# Altium schematic sheet Sensor-Sheet4.SchDoc(Sensor-Sheet4)
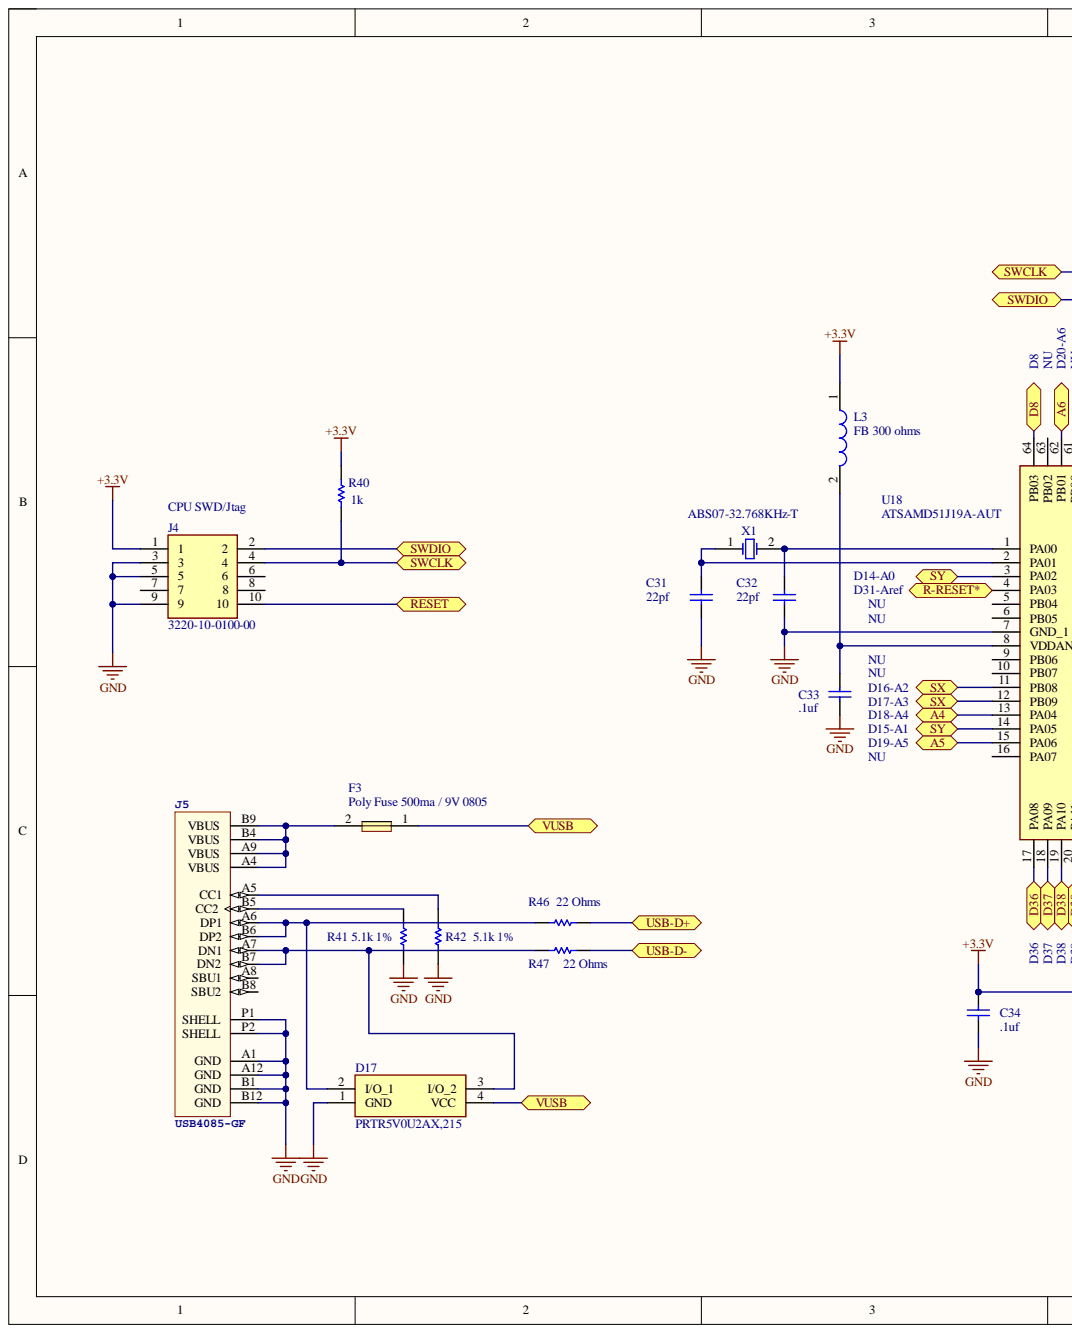
[diagram p0: Sensor-Sheet4.SchDoc, left]
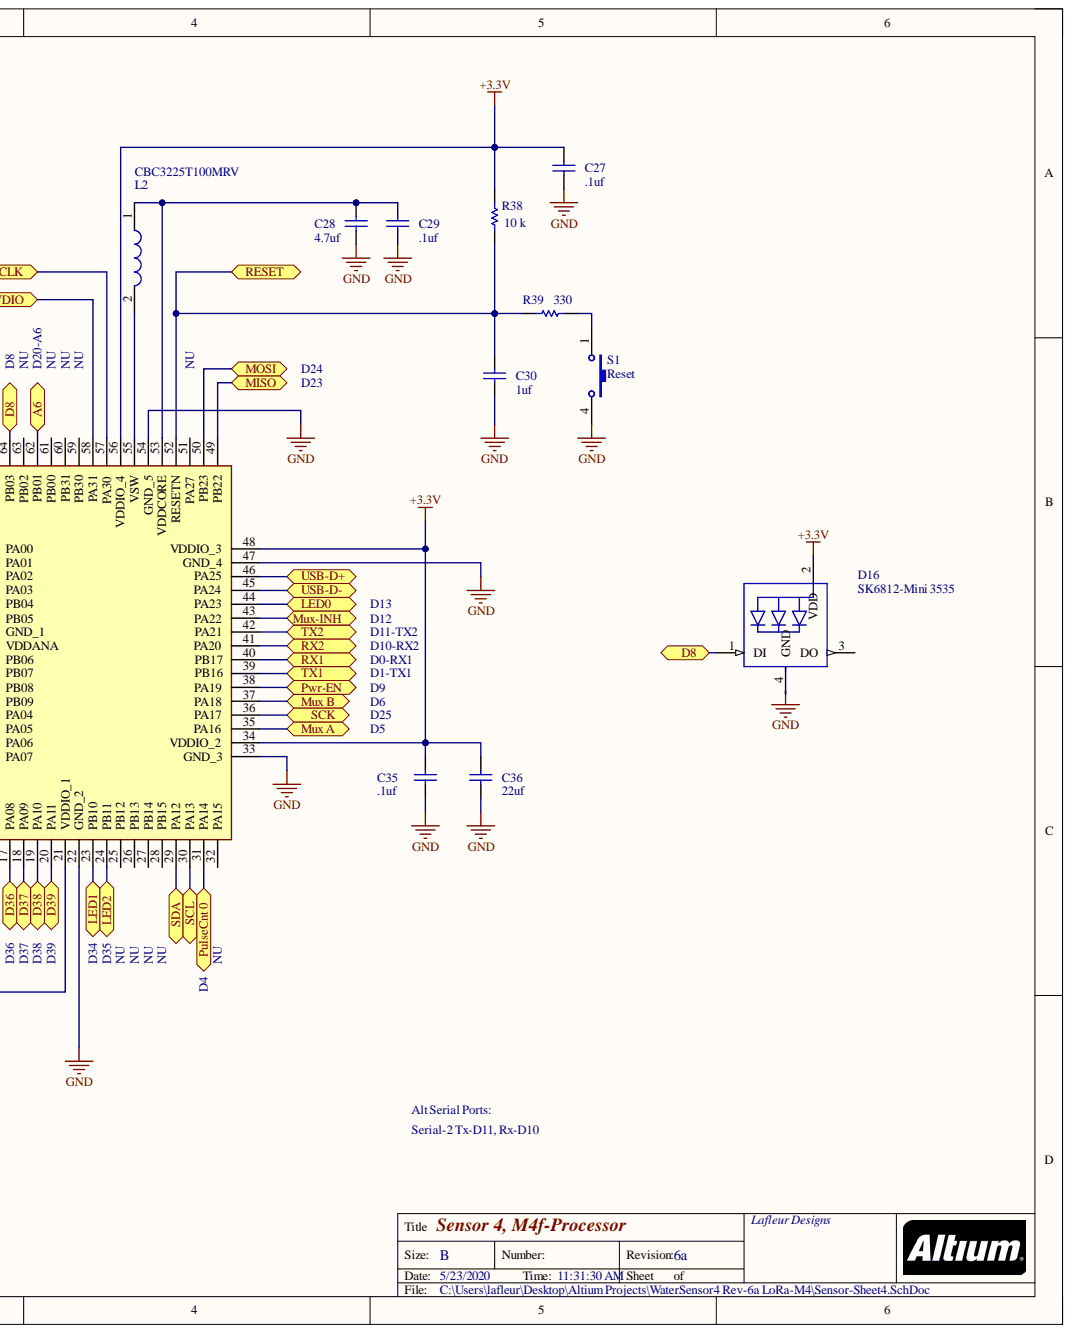
[diagram p1: Sensor-Sheet4.SchDoc, right]

## Parts
C27  .1uf  [c0805]
C28  4.7uf  [C0805]
C29  .1uf  [c0805]
C30  1uf  [c0805]
C31  22pf  [c0805]
C32  22pf  [c0805]
C33  .1uf  [c0805]
C34  .1uf  [c0805]
C35  .1uf  [c0805]
C36  22uf  [c0805]
D16  SK6812-Mini 3535  [SK6816Mini-35x35]
D17  PRTR5V0U2AX,215  [PESD2ETHAXR]
F3  Poly Fuse 500ma / 9V  [6-0805]
J4  3220-10-0100-00  [SHDR10W41P127_2X5_1265X510X565P]
J5  USB4085-GF  [USB4085-GF-A]
L2  CBC3225T100MRV  [INDC3225X270N]
L3  FB 300 ohms  [INDC2012X85N]
R38  10 k  [6-0805]
R39  330  [6-0805]
R40  1k  [6-0805]
R41  5.1k 1%  [6-0805]
R42  5.1k 1%  [6-0805]
R46  22 Ohms  [6-0805]
R47  22 Ohms  [6-0805]
S1  Reset  [KMR_2]
U18  ATSAMD51J19A-AUT  [QFP50P1200X1200X120-64N]
X1  ABS07-32.768KHz-T  [ABRA-ABS07-2_V]

## Ports
A4, A5, A6, D8, D36, D37, D38, D39, LED0, LED1, LED2, MISO, MOSI, Mux A, Mux B, Mux-INH, PulseCnt 0, Pwr-EN, R-RESET*, RESET, RX1, RX2, SCK, SCL, SDA, SWCLK, SWDIO, SX, SY, TX1, TX2, USB-D+, USB-D-, VUSB

## Nets
+3.3V: C27.1 C34.1 C35.1 C36.1 D16.2(VDD) J4.1 L3.1 R38.2 R40.2 U18.21(VDDIO_1) U18.34(VDDIO_2) U18.48(VDDIO_3) U18.56(VDDIO_4)
GND: C27.2 C28.2 C29.2 C30.2 C31.2 C32.2 C33.2 C34.2 C35.2 C36.2 D16.4(GND) D17.1(GND) J4.3 J4.5 J4.9 J5.A1(GND) J5.A12(GND) J5.B1(GND) J5.B12(GND) J5.P1(SHELL) J5.P2(SHELL) R41.2 R42.2 S1.4 U18.7(GND_1) U18.22(GND_2) U18.33(GND_3) U18.47(GND_4) U18.54(GND_5)
A4: U18.13(PA04) ; port A4
A5: U18.15(PA06) ; port A5
A6: U18.62(PB01) ; port A6
D8: D16.1(DI) U18.64(PB03) ; port D8
D36: U18.17(PA08) ; port D36
D37: U18.18(PA09) ; port D37
D38: U18.19(PA10) ; port D38
D39: U18.20(PA11) ; port D39
LED0: U18.44(PA23) ; port LED0
LED1: U18.23(PB10) ; port LED1
LED2: U18.24(PB11) ; port LED2
MISO: U18.49(PB22) ; port MISO
MOSI: U18.50(PB23) ; port MOSI
Mux A: U18.35(PA16) ; port Mux A
Mux B: U18.37(PA18) ; port Mux B
Mux-INH: U18.43(PA22) ; port Mux-INH
PulseCnt 0: U18.31(PA14) ; port PulseCnt 0
Pwr-EN: U18.38(PA19) ; port Pwr-EN
R-RESET*: U18.4(PA03) ; port R-RESET*
RESET: C30.1 J4.10 R38.1 R39.2 U18.52(RESETN) ; port RESET
RX1: U18.40(PB17) ; port RX1
RX2: U18.41(PA20) ; port RX2
SCK: U18.36(PA17) ; port SCK
SCL: U18.30(PA13) ; port SCL
SDA: U18.29(PA12) ; port SDA
SWCLK: J4.4 R40.1 U18.57(PA30) ; port SWCLK
SWDIO: J4.2 U18.58(PA31) ; port SWDIO
SX: U18.11(PB08) U18.12(PB09) ; port SX
SY: U18.3(PA02) U18.14(PA05) ; port SY
TX1: U18.39(PB16) ; port TX1
TX2: U18.42(PA21) ; port TX2
VUSB: D17.4(VCC) F3.1 ; port VUSB
NetC28_1: C28.1 C29.1 L2.1 U18.53(VDDCORE)
NetC31_1: C31.1 U18.2(PA01) X1.1
NetC32_1: C32.1 U18.1(PA00) X1.2
NetC33_1: C33.1 L3.2 U18.8(VDDANA)
NetD16_3: D16.3(DO)
NetD17_2: D17.2(I/O_1) J5.A6(DP1) J5.B6(DP2) R46.1
NetD17_3: D17.3(I/O_2) J5.A7(DN1) J5.B7(DN2) R47.2
NetF3_2: F3.2 J5.A4(VBUS) J5.A9(VBUS) J5.B4(VBUS) J5.B9(VBUS)
NetJ4_6: J4.6
NetJ4_7: J4.7
NetJ4_8: J4.8
NetJ5_A5: J5.A5(CC1) R42.1
NetJ5_A8: J5.A8(SBU1)
NetJ5_B5: J5.B5(CC2) R41.1
NetJ5_B8: J5.B8(SBU2)
NetL2_2: L2.2 U18.55(VSW)
NetR39_1: R39.1 S1.1
NetR46_2: R46.2 U18.46(PA25)
NetR47_1: R47.1 U18.45(PA24)
NetU18_5: U18.5(PB04)
NetU18_6: U18.6(PB05)
NetU18_9: U18.9(PB06)
NetU18_10: U18.10(PB07)
NetU18_16: U18.16(PA07)
NetU18_25: U18.25(PB12)
NetU18_26: U18.26(PB13)
NetU18_27: U18.27(PB14)
NetU18_28: U18.28(PB15)
NetU18_32: U18.32(PA15)
NetU18_51: U18.51(PA27)
NetU18_59: U18.59(PB30)
NetU18_60: U18.60(PB31)
NetU18_61: U18.61(PB00)
NetU18_63: U18.63(PB02)

## Library data of the parts
C27-C36 = CAP_1: Capacitor; Fitted: True; Component Kind: Standard; Library Name: N2K Project.SCHLIB
D16 = SK6812-Mini: Addressable Lighting Neopixel 10 LED Discrete Serial _Shift Register_ Red, Green, Blue _RGB_ 3.50mm L x 3.50mm W; MF: Shenzhen LED Color Optoelectronic Inc.; MP: SK6812; Availability: Unavailable; Component Kind: Standard; Library Name: WaterSensor V4-6a.SCHLIB; Package: SMD-4 Shenzhen LED Color Optoelectronic Inc.; Price: None; SnapEDA_Link: https://www.snapeda.com/parts/SK6812/Shenzhen%20LED%20Color%20Optoelectronic%20Inc./view-part/554016/?ref=snap
D17 = PRTR5V0U2AX,215: TVS Diode (Uni-directional); Manufacturer_Name: Nexperia; Component Kind: Standard; Datasheet Link: https://assets.nexperia.com/documents/data-sheet/PRTR5V0U2AX.pdf; Height: 1mm; Manufacturer_Part_Number: PRTR5V0U2AX,215; Mouser Part Number: 771-PRTR5V0U2AX-T/R; Mouser Price/Stock: https://www.mouser.com/Search/Refine.aspx?Keyword=771-PRTR5V0U2AX-T%2FR; RS Part Number: 7258726P; RS Price/Stock: http://uk.rs-online.com/web/p/products/7258726P
F3 = Poly Fuse: Component Kind: Standard; Description: Poly Fuse; Library Name: N2K Project.SCHLIB
J4 = 3220-10-0100-00: Connector; Manufacturer_Name: CNC Tech; Component Kind: Standard; Datasheet Link: http://www.cnctech.us/pdfs/3220-XX-0100-00.pdf; Height: 5.65mm; Manufacturer_Part_Number: 3220-10-0100-00
J5 = USB4085-GF-A_revA: USB 2.0 Type C Receptacle Dip Type, PCB Top Mount; MANUFACTURER: Global Connector Technology; Component Kind: Standard; Library Name: WaterSensor V4-6_14.SCHLIB; PARTREV: A; STANDARD: Manufacturer Recommendations
L2 = CBC3225T100MRV: Inductor; Manufacturer_Name: TAIYO YUDEN; Component Kind: Standard; Datasheet Link: https://www.mouser.com/datasheet/2/396/taiyo_yuden_01082019_CB-V_-1536735.pdf; Height: 2.7mm; Manufacturer_Part_Number: CBC3225T100MRV; Mouser Part Number: 963-CBC3225T100MRV; Mouser Price/Stock: https://www.mouser.com/Search/Refine.aspx?Keyword=963-CBC3225T100MRV
L3 = MMZ2012Y300B: Inductor; Manufacturer_Name: TDK; Component Kind: Standard; Datasheet Link: https://product.tdk.com/info/en/catalog/datasheets/beads_commercial_signal_mmz2012_en.pdf; Height: 0.85mm; Manufacturer_Part_Number: MMZ2012Y300B; Mouser Part Number: 810-MMZ2012Y300B; Mouser Price/Stock: https://www.mouser.com/Search/Refine.aspx?Keyword=810-MMZ2012Y300B
R38-R42 R46 R47 = ERJ8EN: Precision Thick Film Chip Resistor, 10 Ohm to 2.2M Ohm Range, 1% Tolerance, 1206 Size, 0.125 W; Code_IPC: 3216 [1206]; Component Kind: Standard; ComponentLink1Description: Manufacturer Link; ComponentLink1URL: http://www.panasonic.com/industrial/components/resistive_products.htm; ComponentLink2Description: Datasheet; ComponentLink2URL: http://www.panasonic.com/industrial/components/pdf/AOA0000CE2.pdf; LatestRevisionDate: 19-Mar-2004; LatestRevisionNote: Silkscreen size reduced.; PackageDocument: Mar-2003; PackageReference: 8-1206; Published: 17-Dec-2003; Publisher: Altium Limited
  R41 R42 R46 R47: Library Name: N2K Project.SCHLIB; Signal Integrity: Res; Simulation: RESISTOR
  R38-R40: Library Name: Winmor-Demo-R1.IntLib
S1 = SW-PB: Switch; Component Kind: Standard; LatestRevisionDate: 17-Jul-2002; LatestRevisionNote: Re-released for DXP Platform.; Library Name: Miscellaneous Devices.IntLib; PackageReference: SPST-2; Published: 24-Mar-1999; Publisher: Altium Limited
U18 = ATSAMD51J19A-AUT: Integrated Circuit; Manufacturer_Name: Microchip; Component Kind: Standard; Datasheet Link: http://ww1.microchip.com/downloads/en/DeviceDoc/SAM-D5xE5x-Family-Datasheet-01507B.pdf; Height: 1.2mm; Manufacturer_Part_Number: ATSAMD51J19A-AUT; Mouser Part Number: 556-ATSAMD51J19AAUT; Mouser Price/Stock: https://www.mouser.com/Search/Refine.aspx?Keyword=556-ATSAMD51J19AAUT
X1 = CMP-0447-00001-2: Crystal, 32.768 kHz, 12.5 pF, -40 to 85 degC, SMD Low Profile 3.2 x 1.5 x 0.9 mm, Tape and Reel; Manufacturer: Abracon; Drive Level (Type): 0.1 uW; Equivalent Series Resistance (R1): 70 Kohm; Frequency Tolerance @+25degC: +/- 20 ppm; Insulation Resistance (Min): 500 Mohm; Load Capacitance (CL): 12.5 pF; Operation Mode: Flexural Mode (Tuning Fork); Q Value (Type): 30000; Shunt Capacitance (C0): 0.9 to 1.2 pF; Component Kind: Standard; ComponentLink1Description: Manufacturer URL; ComponentLink1URL: http://www.abracon.com/; ComponentLink2Description: Datasheet; ComponentLink2URL: http://www.abracon.com/Resonators/ABS07.pdf; ComponentLink3Description: Package Specification; ComponentLink3URL: http://www.abracon.com/Resonators/ABS07.pdf; DatasheetVersion: 04.03.13; Frequency: 32.768 kHz; Library Name: Altium Content Vault; Mounting Technology: Surface Mount; Operating Temperature: -40 to 85 degC; PackageDescription: 2-Pin Hand Drawn, Body 3.2x1.5mm, Pitch 2.5mm; PackageReference: ABS07-2; Packing: Tape and Reel; PartNumber: ABS07-32.768KHz-T
